# Altium schematic sheet ATMega.SchDoc(ATMega)
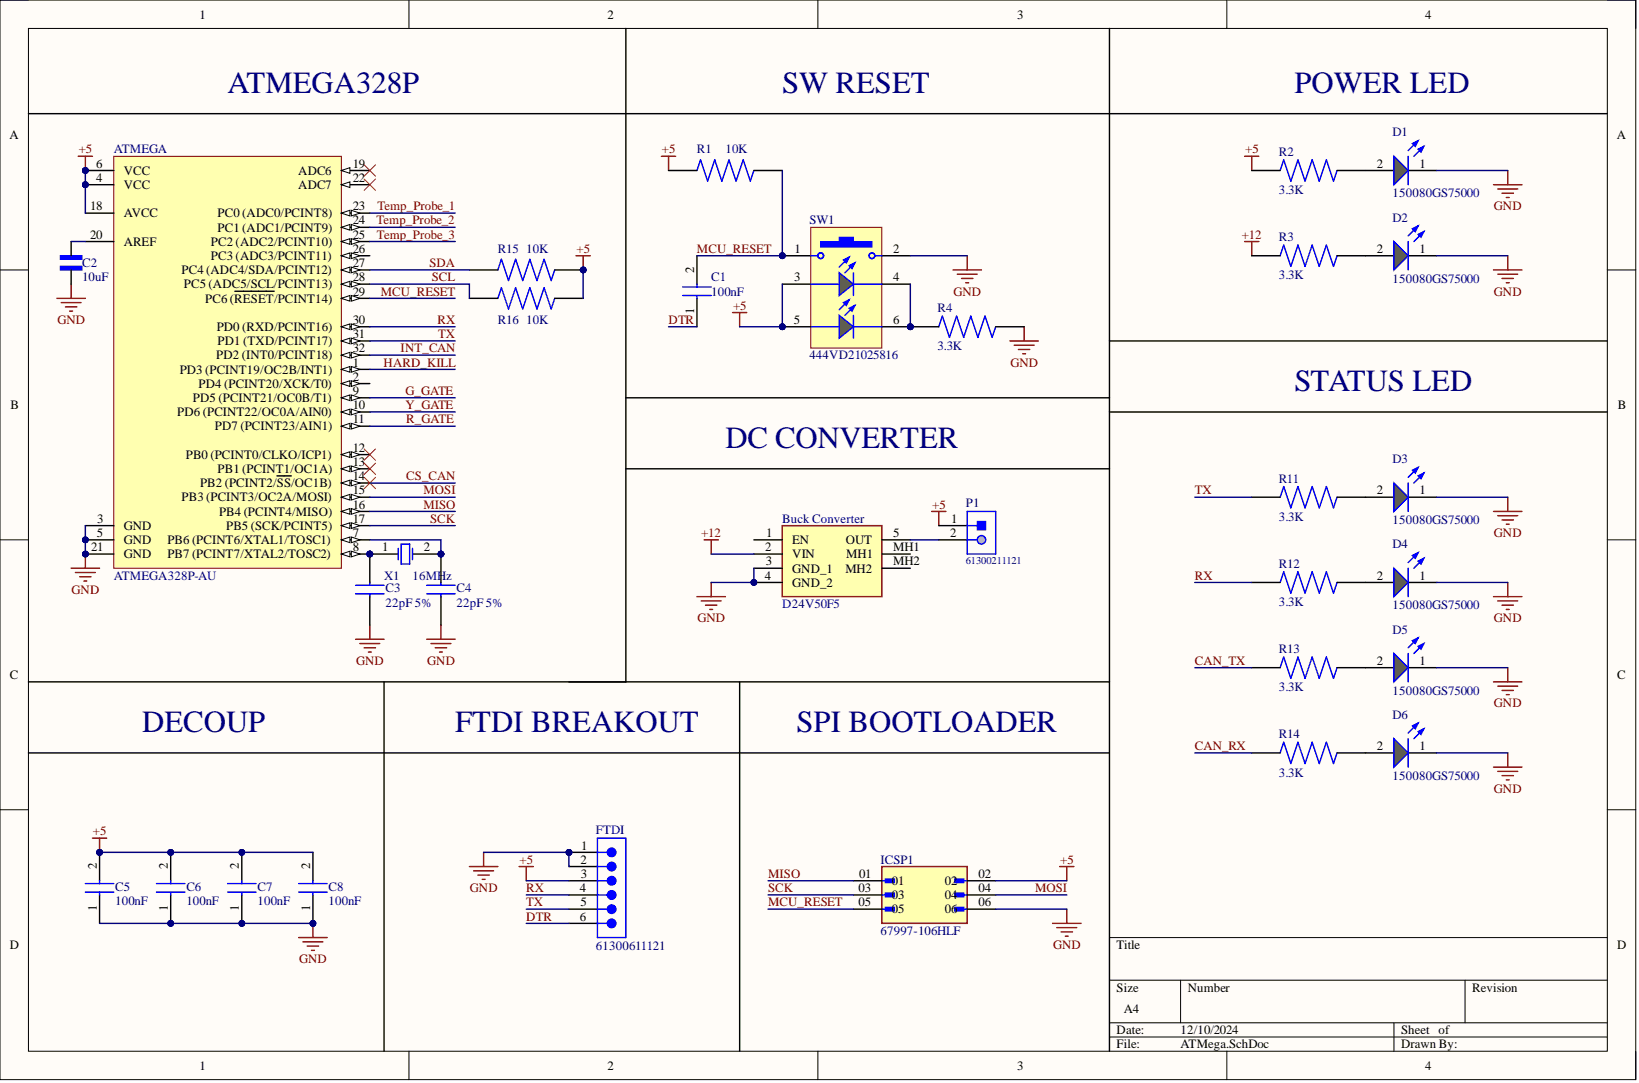
[diagram p0: ATMega.SchDoc]

## Parts
ATMEGA  ATMEGA328P-AU
Buck_Converter  D24V50F5
C1  100nF
C2  10uF
C3  22pF 5%
C4  22pF 5%
C5  100nF
C6  100nF
C7  100nF
C8  100nF
D1  150080GS75000
D2  150080GS75000
D3  150080GS75000
D4  150080GS75000
D5  150080GS75000
D6  150080GS75000
FTDI  61300611121
ICSP1  67997-106HLF
P1  61300211121
R1  10K
R2  3.3K
R3  3.3K
R4  3.3K
R11  3.3K
R12  3.3K
R13  3.3K
R14  3.3K
R15  10K
R16  10K
SW1  444VD21025816
X1  16MHz

## Nets
+5: ATMEGA.4(VCC) ATMEGA.6(VCC) ATMEGA.18(AVCC) C5.2 C6.2 C7.2 C8.2 FTDI.3 ICSP1.02 P1.1 R1.1 R2.1 R15.2 R16.2 SW1.3 SW1.5
+12: Buck_Converter.2(VIN) R3.1
GND: ATMEGA.3(GND) ATMEGA.5(GND) ATMEGA.21(GND) Buck_Converter.3(GND_1) Buck_Converter.4(GND_2) C2.1 C3.1 C4.1 C5.1 C6.1 C7.1 C8.1 D1.1 D2.1 D3.1 D4.1 D5.1 D6.1 FTDI.1 FTDI.2 ICSP1.06 R4.2 SW1.2
CAN_RX: R14.1
CAN_TX: R13.1
CS_CAN: ATMEGA.14(PB2_(PCINT2/SS/OC1B))
DTR: C1.1 FTDI.6
G_GATE: ATMEGA.9(PD5_(PCINT21/OC0B/T1))
HARD_KILL: ATMEGA.1(PD3_(PCINT19/OC2B/INT1))
INT_CAN: ATMEGA.32(PD2_(INT0/PCINT18))
MCU_RESET: ATMEGA.29(PC6_(RESET/PCINT14)) C1.2 ICSP1.05 R1.2 SW1.1
MISO: ATMEGA.16(PB4_(PCINT4/MISO)) ICSP1.01
MOSI: ATMEGA.15(PB3_(PCINT3/OC2A/MOSI)) ICSP1.04
R_GATE: ATMEGA.11(PD7_(PCINT23/AIN1))
RX: ATMEGA.30(PD0_(RXD/PCINT16)) FTDI.4 R12.1
SCK: ATMEGA.17(PB5_(SCK/PCINT5)) ICSP1.03
SCL: ATMEGA.28(PC5_(ADC5/SCL/PCINT13)) R16.1
SDA: ATMEGA.27(PC4_(ADC4/SDA/PCINT12)) R15.1
Temp_Probe_1: ATMEGA.23(PC0_(ADC0/PCINT8))
Temp_Probe_2: ATMEGA.24(PC1_(ADC1/PCINT9))
Temp_Probe_3: ATMEGA.25
TX: ATMEGA.31(PD1_(TXD/PCINT17)) FTDI.5 R11.1
Y_GATE: ATMEGA.10(PD6_(PCINT22/OC0A/AIN0))
NetATMEGA_7: ATMEGA.7 C4.2 X1.2
NetATMEGA_8: ATMEGA.8 C3.2 X1.1
NetATMEGA_20: ATMEGA.20(AREF) C2.2
NetBuck Converter_5: Buck_Converter.5(OUT) P1.2
NetD1_2: D1.2 R2.2
NetD2_2: D2.2 R3.2
NetD3_2: D3.2 R11.2
NetD4_2: D4.2 R12.2
NetD5_2: D5.2 R13.2
NetD6_2: D6.2 R14.2
NetR4_1: R4.1 SW1.4 SW1.6

Not connected: ATMEGA 2(PD4_(PCINT20/XCK/T0)) 12(PB0_(PCINT0/CLKO/ICP1)) 13(PB1_(PCINT1/OC1A)) 19(ADC6) 22(ADC7) 26(PC3_(ADC3/PCINT11)); Buck_Converter 1(EN) MH1 MH2

## Library data of the parts
ATMEGA = CMP-00019-1: 8-bit AVR MCU, 32 KB, 2048 Bytes, 20 MHz, 1.8 to 5.5V, TQFP-32, RoHS; Component Kind: Standard; Library Name: BumbleBee Autonomous Systems - NUS
Buck_Converter = CMP-082-000000-1: Pololu 5V, 5A Step-Down Voltage Regulator D24V50F5; Geometry.Height: 8.77mm; Component Kind: Standard; Datasheet Link: https://www.pololu.com/file/0J1436/d24v50f5-step-down-voltage-regulator-dimensions.pdf; Design Item ID: D24V50F5; Manufacturer_Name: Pololu; Manufacturer_Part_Number: D24V50F5; Source: D24V50F5.SchLib; Library Name: BumbleBee Autonomous Systems - NUS
C1 C5-C8 = CMP-061-000001-1: Capacitor; Manufacturer_Name: Wurth Elektronik; Manufacturer_Part_Number: 885012207098R; Component Kind: Standard; Datasheet Link: https://www.we-online.com/en/components/products/WCAP-CSGP-50VDC#885012207098R; Design Item ID: 885012207098R; Height: 0.9mm; Source: SamacSys.SchLib; Library Name: BumbleBee Autonomous Systems - NUS
C2 = CMP-226-000000-1: WCAP-CSGP General Purpose MLCC; SMT 0805; X7R; 10?F 10%; 10V; -55C to +125C; Tech. PN X7R0805106K010DFCT10000; MF: Wurth Electronics Inc; MP: 885012207026; Component Kind: Standard; Component Type: Standard; Design Item ID: 885012207026; Digi-Key_Part_Number: 732-7658-1-ND; Digi-Key_Purchase_URL: https://www.digikey.com/product-detail/en/w-rth-elektronik/885012207026/732-7658-1-ND/5454285?utm_source=snapeda&utm_medium=aggregator&utm_campaign=symbol; Package: 0805 Wurth Electronics Inc; Pin Count: 2; SnapEDA_Link: https://www.snapeda.com/parts/885012207026/Wurth%20Electronics%20Inc/view-part/4217194/?ref=snap; Source: SnapEDAsch.SchLib; Library Name: BumbleBee Autonomous Systems - NUS
C3 C4 = CMP-015-000000-3: 885012007053 Multilayer Ceramic Capacitors MLCC - SMD/SMT WCAP-CSGP 22pF 0805 5% 50V MLCC; Manufacturer_Name: Wurth Elektronik; Geometry.Height: 0.7mm; Component Kind: Standard; Datasheet Link: https://katalog.we-online.com/pbs/datasheet/885012007053.pdf; Design Item ID: 885012007053; Manufacturer_Part_Number: 885012007053; Mouser Part Number: 710-885012007053; Mouser Price/Stock: https://www.mouser.co.uk/ProductDetail/Wurth-Elektronik/885012007053?qs=0KOYDY2FL285f7xXUJLtKA%3D%3D; Source: 885012007053.SchLib; Library Name: BumbleBee Autonomous Systems - NUS
D1-D6 = CMP-1426-00007-2: NexarPartId: 29717780; Color: Green; Dominant Wavelength: 525nm; Forward Current: 100mA; Forward Voltage: 3.2V; Lens Style: Clear, Rectangular; Luminous Intensity: 450mcd; Max Junction Temperature (Tj): 95°C; Max Reverse Voltage (DC): 5V; Peak Wavelength: 515nm; Power Dissipation: 105mW; Test Current: 20mA; Viewing Angle: 140°; Wavelength: 520nm; Case Code (Imperial): 0805; Case Code (Metric): 2012; Case/Package: 0805; Component Kind: Standard; Height: 700µm; Length: 2mm; Library Name: Altium Content Vault; Max Operating Temperature: 85°C; Min Operating Temperature: -40°C; Mount: Surface Mount; Packaging: Tape and Reel; REACH SVHC: Unknown; Reverse Voltage: 5V; RoHS: Compliant; Width: 1.25mm
FTDI = CMP-1502-01070-2: NexarPartId: 20084590; Connector Type: Male Pin; Contact Resistance: 20mΩ; Current Rating: 3A; Gender: Male; Number of Contacts: 6; Operating Supply Voltage: 250V; Pitch: 2.54mm; Color: Black; Component Kind: Standard; Contact Gender: Male; Contact Material: Copper; Contact Mating Length: 5.9944mm; Contact Plating: Gold; Height: 8.89mm; Housing Material: PBT; Lead Free: Lead Free; Lead Pitch: 2.54mm; Library Name: Altium Content Vault; Max Operating Temperature: 105°C; Min Operating Temperature: -40°C; Mount: Through Hole; Number of Pins: 6; Number of Positions: 6; Number of Rows: 1; Orientation: Vertical; Packaging: Bulk; REACH SVHC: Unknown; RoHS: Compliant; Termination: Through Hole; Voltage Rating: 250V
ICSP1 = CMP-141-000001-1: BergStikÂ®, Board to Board connector, Unshrouded vertical header, Through Hole, Double Row, 6 Positions, 2.54 mm (0.100in) Pitch; MF: Amphenol ICC; Availability: In Stock; Check_prices: https://www.snapeda.com/parts/67997-106HLF/Amphenol+FCI/view-part/?ref=eda; Component Kind: Standard; Component Type: Standard; Design Item ID: 67997-106HLF; MP: 67997-106HLF; Package: None; Pin Count: 6; Price: None; Purchase-URL: https://pricing.snapeda.com/search/part/67997-106HLF/?ref=eda; SnapEDA_Link: https://www.snapeda.com/parts/67997-106HLF/Amphenol+FCI/view-part/?ref=snap; Source: 67997-106HLF.SchLib; Library Name: BumbleBee Autonomous Systems - NUS
P1 = CMP-00045-1: THT Vertical Pin Header WR-PHD, Pitch 2.54 mm, Single Row, 2 pins; Current Rating: 3A; Gender: Male; Number of Contacts: 2; Pitch: 0.1inch; Voltage Rating: 250V; Color: Black; Component Kind: Standard; Contact Gender: Male; Contact Material: Copper Alloy,Copper; Contact Mating Length: 0.236inch; Contact Plating: Gold; Contact Type: Male Pin; Housing Material: PBT; Lead Free: Lead Free; Max Operating Temperature: 125°C; Min Operating Temperature: -40°C; Mounting Technology: Through Hole; Number of Positions: 2; Number of Rows: 1; Packaging: Bulk; Reach SVHC Compliant: Unknown; RoHS Compliant: Yes; Series: WR-PHD; Termination: Through Hole; Library Name: BumbleBee Autonomous Systems - NUS
R1 R15 R16 = CMP-147-000016-1: 10 kOhms Â±1% 0.125W, 1/8W Chip Resistor 0805 _2012 Metric_ Automotive AEC-Q200 Thick Film; MF: Vishay; MP: CRCW080510K0FKEA; Availability: Unavailable; Component Kind: Standard; Component Type: Standard; Design Item ID: CRCW080510K0FKEA; Package: 2012 Vishay; Pin Count: 2; Price: None; SnapEDA_Link: https://www.snapeda.com/parts/CRCW080510K0FKEA/Vishay%20Dale/view-part/47363/?ref=snap; Source: SnapEDAsch.SchLib; Library Name: BumbleBee Autonomous Systems - NUS
R2-R4 R11-R14 = CMP-147-000017-1: Crcw08053k30fkea Res Thic k Film 0805 3.3k Ohm 1% 1 /8w ?100ppm/?c Molded Smd Paper t/r Rohs; MF: Vishay Dale; Arrow_Europe-Purchase-URL: https://snapeda.com/shop?store=Arrow+Europe&id=382525; Availability: Good; Component Kind: Standard; Component Type: Standard; Design Item ID: CRCW08053K30FKEA; Mouser-Purchase-URL: https://snapeda.com/shop?store=Mouser&id=382525; MP: CRCW08053K30FKEA; Package: 2012 Vishay; Pin Count: 2; Price: None; SnapEDA_Link: https://www.snapeda.com/parts/CRCW08053K30FKEA/Vishay%20Dale/view-part/382525/?ref=snap; Source: CRCW08053K30FKEA.SchLib; Library Name: BumbleBee Autonomous Systems - NUS
SW1 = CMP-1465-00007-2: NexarPartId: 31136487; Actuator Length: 500µm; Actuator Material: Liquid Crystal Polymer, Polymer; Contact Current Rating: 50mA; Dominant Wavelength: 567nm; Forward Current: 150mA; Forward Voltage: 2.1V; Illumination Type: Illuminated, LED; Insulation Resistance: 100MΩ; Luminous Intensity: 50mcd; Mechanical Life: 50kcycles; Peak Wavelength: 574nm; Reverse Voltage: 5V; Switch Function: Off-Mom; Switch Travel: 250µm; Throw Configuration: SPST; Viewing Angle: 145°; Voltage Rating: 12V; Color: White; Component Kind: Standard; Contact Material: Stainless Steel, Steel; Contact Plating: Silver; Current Rating: 50mA; Height: 2.5mm; Length: 6.8mm; Library Name: Altium Content Vault; Max Operating Temperature: 85°C; Min Operating Temperature: -40°C; Mount: Surface Mount; REACH SVHC: No SVHC; RoHS: Compliant; Termination: Gull Wing; Width: 4.5mm
X1 = CMP-00008-1: Crystal 16MHz 20pF 2-Pin HC49/US SM T/R; ESR: 40R; Frequency Stability: 50ppm; Frequency Tolerance: 30ppm; Component Kind: Standard; ESR (Equivalent Series Resistance): 40R; Frequency: 16MHz; Height: 0.169inch; Length: 0.437inch; Load Capacitance: 20pF; Max Operating Temperature: 70°C; Min Operating Temperature: -20°C; Mounting Technology: Surface Mount; Package Quantity: 1000; Packaging: Tape and Reel; Pins: 2; RoHS Compliant: Yes; Series: ATS-SM; Termination: SMD/SMT; Tolerance: 30ppm; Width: 3.8mm; Library Name: BumbleBee Autonomous Systems - NUS
